# Altium schematic sheet S07_Ethernet_RevF.SchDoc
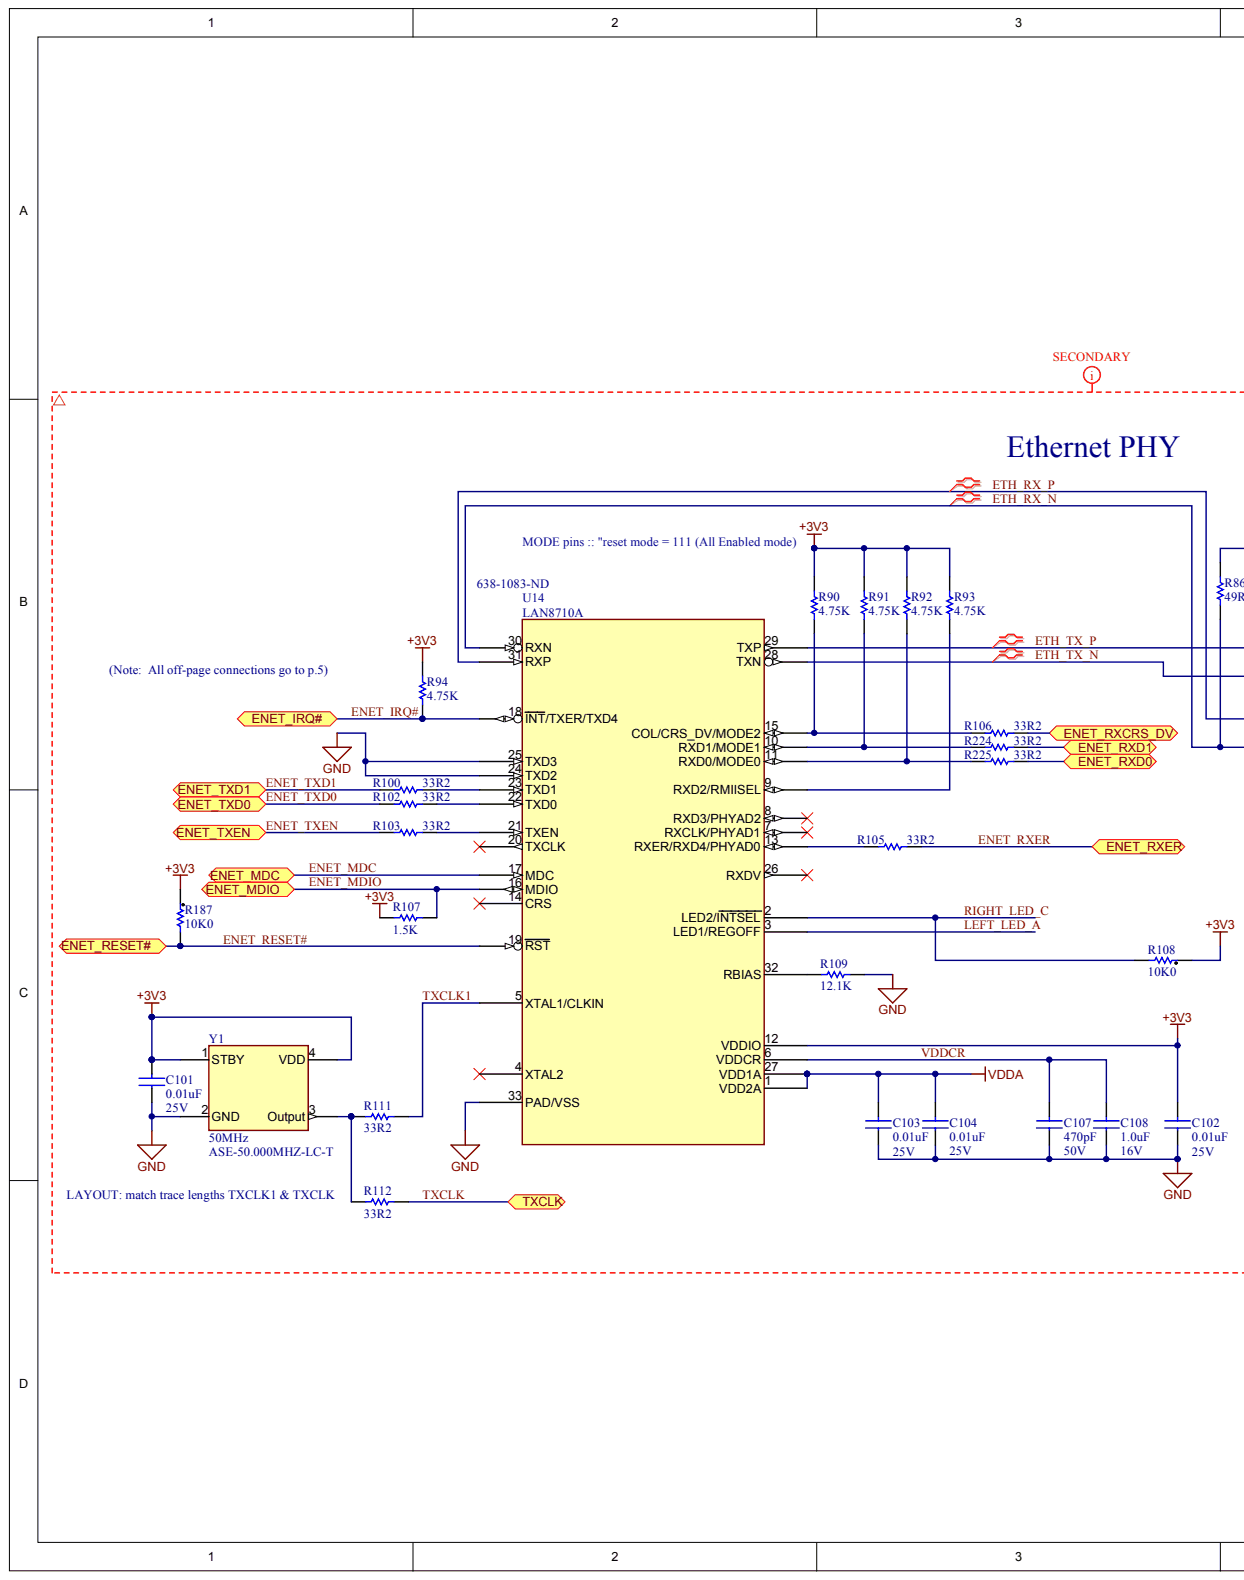
[diagram p0: S07_Ethernet_RevF.SchDoc, left]
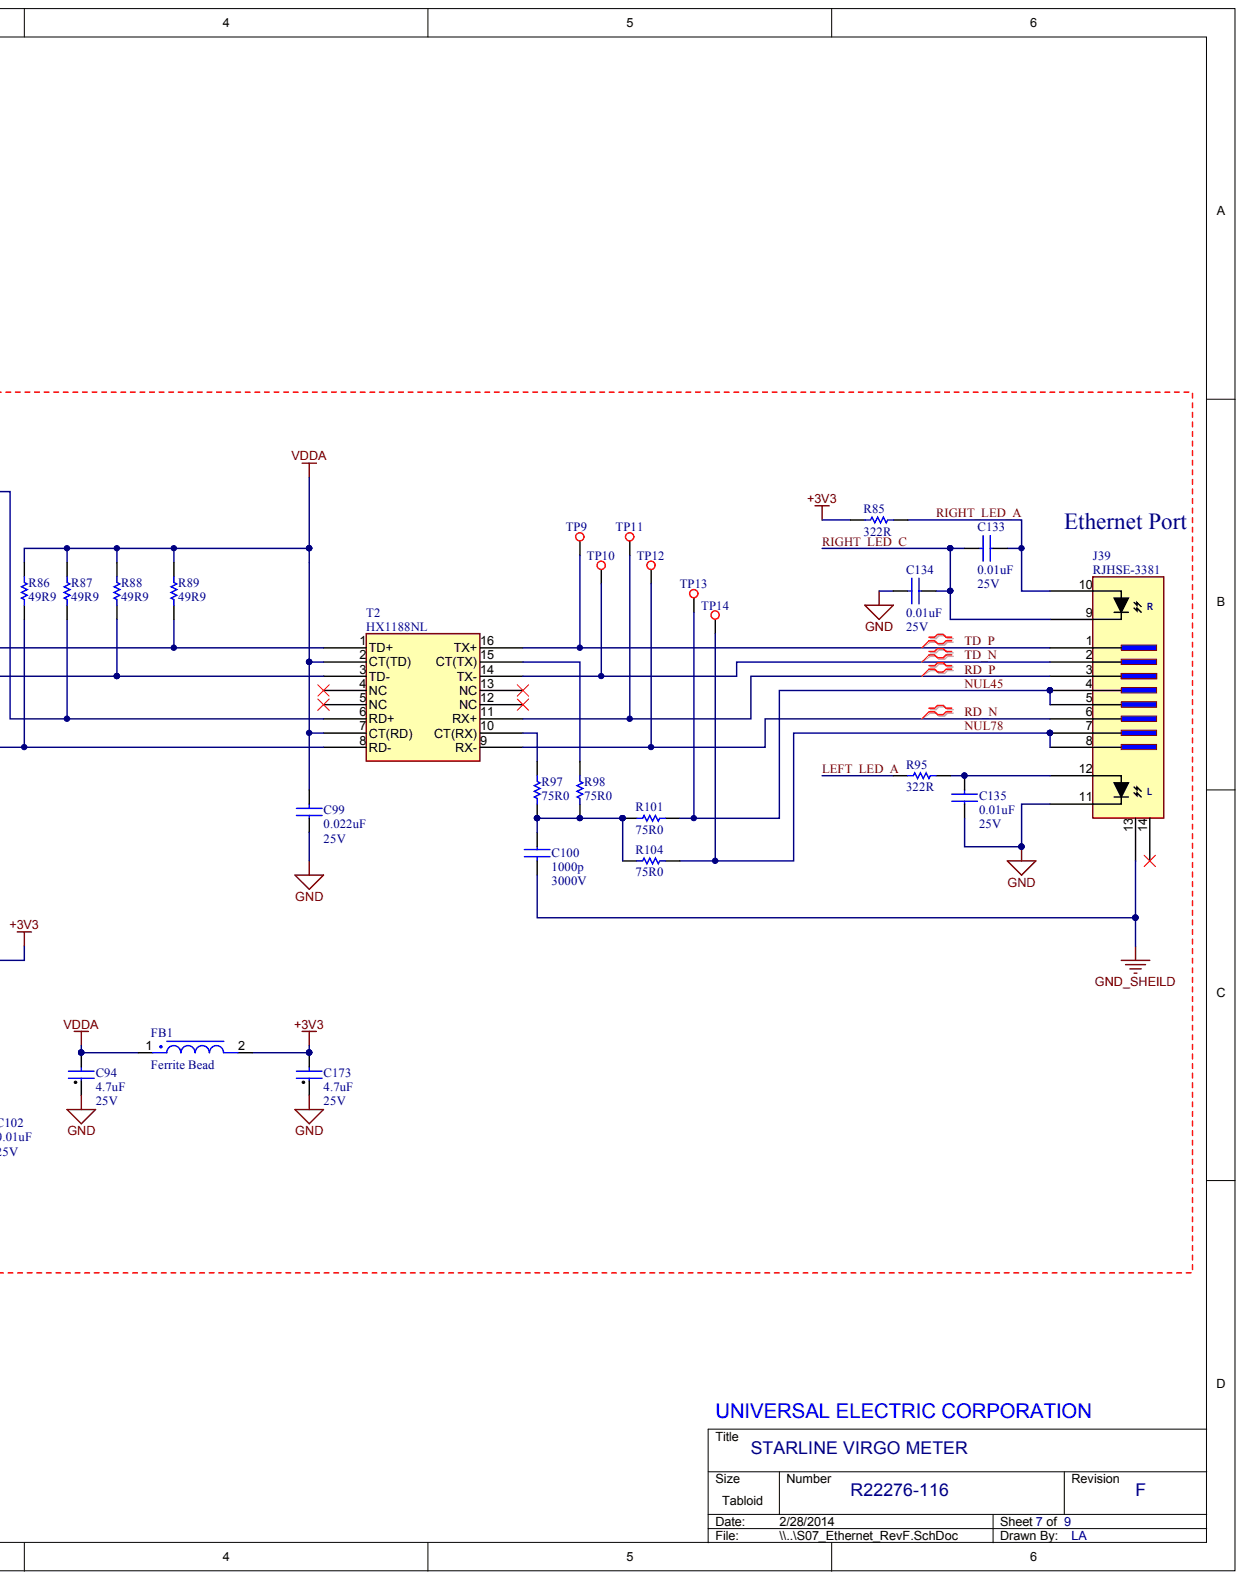
[diagram p1: S07_Ethernet_RevF.SchDoc, right]

## Parts
C94  Voltage: 25V  (hidden comment: 4.7uF)
C99  value 0.022uF  Voltage: 25V  (hidden comment: CAP CER 0.022uF X7R 10% 25V SM_0402)
C100  VOLTAGE: 3000V  (hidden comment: 1000p)
C101  value 0.01uF  Voltage: 25V  (hidden comment: CAP CER 0.01uF X7R 10% 25V SM_0402)
C102  value 0.01uF  Voltage: 25V  (hidden comment: CAP CER 0.01uF X7R 10% 25V SM_0402)
C103  value 0.01uF  Voltage: 25V  (hidden comment: CAP CER 0.01uF X7R 10% 25V SM_0402)
C104  value 0.01uF  Voltage: 25V  (hidden comment: CAP CER 0.01uF X7R 10% 25V SM_0402)
C107  value 470pF  Voltage: 50V  (hidden comment: CAP CER 470pF C0G 5% 50V SM_0402)
C108  value 1.0uF  Voltage: 16V  (hidden comment: CAP CER 1.0uF X7R 20% 16V SM_0805)
C133  value 0.01uF  Voltage: 25V  (hidden comment: CAP CER 0.01uF X7R 10% 25V SM_0402)
C134  value 0.01uF  Voltage: 25V  (hidden comment: CAP CER 0.01uF X7R 10% 25V SM_0402)
C135  value 0.01uF  Voltage: 25V  (hidden comment: CAP CER 0.01uF X7R 10% 25V SM_0402)
C173  Voltage: 25V  (hidden comment: 4.7uF)
FB1  Ferrite Bead
J39  value RJHSE-3381  MFG_PART_NO: RJHSE-3381  (hidden comment: RJ45)
R85  value 322R  (hidden comment: RES 332R 1/16W 1% SM_0402)
R86  value 49R9  (hidden comment: RES 49R9 1/16W 1% SM_0402)
R87  value 49R9  (hidden comment: RES 49R9 1/16W 1% SM_0402)
R88  value 49R9  (hidden comment: RES 49R9 1/16W 1% SM_0402)
R89  value 49R9  (hidden comment: RES 49R9 1/16W 1% SM_0402)
R90  value 4.75K  (hidden comment: RES 4.75K 1/16W 1% SM_0402)
R91  value 4.75K  (hidden comment: RES 4.75K 1/16W 1% SM_0402)
R92  value 4.75K  (hidden comment: RES 4.75K 1/16W 1% SM_0402)
R93  value 4.75K  (hidden comment: RES 4.75K 1/16W 1% SM_0402)
R94  value 4.75K  (hidden comment: RES 4.75K 1/16W 1% SM_0402)
R95  value 322R  (hidden comment: RES 332R 1/16W 1% SM_0402)
R97  value 75R0  (hidden comment: RES 75R0 1/16W 1% SM_0402)
R98  value 75R0  (hidden comment: RES 75R0 1/16W 1% SM_0402)
R100  value 33R2  (hidden comment: RES 33R2 1/16W 1% SM_0402)
R101  value 75R0  (hidden comment: RES 75R0 1/16W 1% SM_0402)
R102  value 33R2  (hidden comment: RES 33R2 1/16W 1% SM_0402)
R103  value 33R2  (hidden comment: RES 33R2 1/16W 1% SM_0402)
R104  value 75R0  (hidden comment: RES 75R0 1/16W 1% SM_0402)
R105  value 33R2  (hidden comment: RES 33R2 1/16W 1% SM_0402)
R106  value 33R2  (hidden comment: RES 33R2 1/16W 1% SM_0402)
R107  value 1.5K  (hidden comment: RES 1.5K 1/16W 1% SM_0402)
R108  10K0
R109  value 12.1K  (hidden comment: RES 12.1K 1/16W 1% SM_0402)
R111  value 33R2  (hidden comment: RES 33R2 1/16W 1% SM_0402)
R112  value 33R2  (hidden comment: RES 33R2 1/16W 1% SM_0402)
R187  10K0
R224  value 33R2  (hidden comment: RES 33R2 1/16W 1% SM_0402)
R225  value 33R2  (hidden comment: RES 33R2 1/16W 1% SM_0402)
T2  MFG_PART_NO: HX1188NL  (hidden comment: 1:1)
TP9  =VALUE
TP10  =VALUE
TP11  =VALUE
TP12  =VALUE
TP13  =VALUE
TP14  =VALUE
U14  Supplier Part Number 1: 638-1083-ND  (hidden comment: LAN8710A)
Y1  MFG_PART_NO: ASE-50.000MHZ-LC-T  (hidden comment: 50MHz)

## Ports
ENET_IRQ#, ENET_MDC, ENET_MDIO, ENET_RESET#, ENET_RXCRS_DV, ENET_RXD0, ENET_RXD1, ENET_RXER, ENET_TXD0, ENET_TXD1, ENET_TXEN, TXCLK

## Nets
+3V3: C101.2 C102.2 C173.2 FB1.2 R85.1 R90.2 R91.2 R92.2 R93.2 R94.2 R107.1 R108.1 R187.1 U14.12(VDDIO) Y1.1(STBY) Y1.4(VDD)
GND: C94.1 C99.1 C101.1 C102.1 C103.1 C104.1 C107.1 C108.1 C134.2 C135.1 C173.1 J39.11 R109.2 U14.24(TXD2) U14.25(TXD3) U14.33(PAD/VSS) Y1.2(GND)
GND_SHEILD: C100.2 J39.13
VDDA: C94.2 C99.2 C103.2 C104.2 FB1.1 R86.2 R87.2 R88.2 R89.2 T2.2(CT(TD)) T2.7(CT(RD)) U14.1(VDD2A) U14.27(VDD1A)
ENET_IRQ#: R94.1 U14.18(INT/TXER/TXD4) ; port ENET_IRQ#
ENET_MDC: U14.17(MDC) ; port ENET_MDC
ENET_MDIO: R107.2 U14.16(MDIO) ; port ENET_MDIO
ENET_RESET#: R187.2 U14.19(RST) ; port ENET_RESET#
ENET_RXCRS_DV: R106.2 ; port ENET_RXCRS_DV
ENET_RXD0: R225.2 ; port ENET_RXD0
ENET_RXD1: R224.2 ; port ENET_RXD1
ENET_RXER: R105.2 ; port ENET_RXER
ENET_TXD0: R102.1 ; port ENET_TXD0
ENET_TXD1: R100.1 ; port ENET_TXD1
ENET_TXEN: R103.1 ; port ENET_TXEN
ETH_RX_N: R86.1 T2.8(RD-) U14.30(RXN)
ETH_RX_P: R87.1 T2.6(RD+) U14.31(RXP)
ETH_TX_N: R88.1 T2.3(TD-) U14.28(TXN)
ETH_TX_P: R89.1 T2.1(TD+) U14.29(TXP)
LEFT_LED_A: R95.1 U14.3(LED1/REGOFF)
NUL45: J39.4 J39.5 R101.1 TP13.1
NUL78: J39.7 J39.8 R104.1 TP14.1
RD_N: J39.6 T2.9(RX-) TP12.1
RD_P: J39.3 T2.11(RX+) TP11.1
RIGHT_LED_A: C133.1 J39.10 R85.2
RIGHT_LED_C: C133.2 C134.1 J39.9 R108.2 U14.2(LED2/INTSEL)
TD_N: J39.2 T2.14(TX-) TP10.1
TD_P: J39.1 T2.16(TX+) TP9.1
TXCLK: R112.2 ; port TXCLK
TXCLK1: R111.2 U14.5(XTAL1/CLKIN)
VDDCR: C107.2 C108.2 U14.6(VDDCR)
NetC100_1: C100.1 R97.1 R98.1 R101.2 R104.2
NetC135_2: C135.2 J39.12 R95.2
NetR90_1: R90.1 R106.1 U14.15(COL/CRS_DV/MODE2)
NetR91_1: R91.1 R224.1 U14.10(RXD1/MODE1)
NetR92_1: R92.1 R225.1 U14.11(RXD0/MODE0)
NetR93_1: R93.1 U14.9(RXD2/RMIISEL)
NetR97_2: R97.2 T2.10(CT(RX))
NetR98_2: R98.2 T2.15(CT(TX))
NetR100_2: R100.2 U14.23(TXD1)
NetR102_2: R102.2 U14.22(TXD0)
NetR103_2: R103.2 U14.21(TXEN)
NetR105_1: R105.1 U14.13(RXER/RXD4/PHYAD0)
NetR109_1: R109.1 U14.32(RBIAS)
NetR111_1: R111.1 R112.1 Y1.3(Output)
N00382:

Not connected: J39 14; T2 4(NC) 5(NC) 12(NC) 13(NC); U14 4(XTAL2) 7(RXCLK/PHYAD1) 8(RXD3/PHYAD2) 14(CRS) 20(TXCLK) 26(RXDV)

## Library data of the parts
C94 C173 = (no symbol name): MFG_PART_NO: GRM21BR61E475KA12L; MFG: Murata; Value: 4.7uF
C99 = (no symbol name): MFG_PART_NO: C1005X7R1E223K; MFG: TDK
C100 = (no symbol name): MFG_PART_NO: C1808X102KHRACTU; LEVEL: Level_1; MFG: Kemet; TYPE: Capacitor; TOLERANCE: +/- 10%; NUV_DESCRIPTION: CAP CER 1000PF 3KV 10% X7R 1808; NOSTUFF: *; ROOM: *; VALUE: 1000p; PACKAGE: SMT; ROHS: RoHS; LAST_UPDATE: 1/18/2012; Created By: K Desrochers
C101-C104 C133-C135 = (no symbol name): MFG_PART_NO: GRM155R71E103KA01D; MFG: Murata
C107 = (no symbol name): MFG_PART_NO: GRM1555C1H471JA01D; MFG: Murata
C108 = (no symbol name): MFG_PART_No: C2012X7R1C105K; MFG: TDK
FB1 = (no symbol name): Manufacturer P/N: MZ1608-102Y; Manufacturer: Bourns; Frequency: 100MHZ; Current Rating: 100mA; Package: SM_0603
J39 = (no symbol name): LEVEL: Level_1; MFG: Amphenol; NUV_DESCRIPTION: RJ45 Ethernet Jacl Thru-Hole Vertical; NOSTUFF: *; TYPE: Connector; PACKAGE: Thru-Hole; ROHS: RoHS; LAST_UPDATE: 6/22/2012; Created By: K Desrochers
R85 R95 = (no symbol name): MFG_PART_NO: CRCW0402332RFKED; MFG: Vishay
R86-R89 = (no symbol name): MFG_PART_NO: CRCW040249R9FKED; MFG: Vishay
R90-R94 = (no symbol name): MFG_PART_NO: CRCW04024K75FKED; MFG: Vishay
R97 R98 R101 R104 = (no symbol name): MFG_PART_NO: CRCW040275R0FKED; MFG: Vishay
R100 R102 R103 R105 R106 R111 R112 R224 R225 = (no symbol name): MFG_PART_NO: CRCW040233R2FKED; MFG: Vishay
R107 = (no symbol name): MFG_PART_NO: CRCW04021K5FKED; MFG: Vishay
R108 R187 = (no symbol name): Part Number: CRCW040210K0FKED; Manufacturer: Vishay; Power: 1/16W; Tolerance: 0.01; RESISTANCE: 10K0; Manufacturer P/N: CRCW040210K0FKED; Package: SMT; Type: Resistor
R109 = (no symbol name): MFG_PART_NO: CRCW040212K1FKED; MFG: Vishay
T2 = (no symbol name): LEVEL: Level_1; MFG: Pulse; TYPE: Transformer; NUV_DESCRIPTION: MODULE XFRMR SGL ETHR LAN 16SOIC; VALUE: 1:1; PACKAGE: SMT; ROHS: RoHS; LAST_UPDATE: 6/22/2012; Created By: K Desrochers
TP9-TP14 = (no symbol name): SYM_LVL: LEVEL1; LEVEL: Level_1; NUV_DESCRIPTION: Test Point; ROOM: *; NOSTUFF: NOSTUFF; TYPE: Test Point; PACKAGE: SMT; ROHS: RoHS; LAST_UPDATE: 6/21/2012; Created By: K Desrochers
U14 = (no symbol name): MFG_PART_NO: LAN8710AI-EZK; LEVEL: Level_1; PCBLIB: UEC01.PcbLib; MFG: SMSC; NOTES: Footprint created using LP Wizard; NUV_DESCRIPTION: Single-Chip Ethernet Physical Layer Transceiver; TYPE: IC; VALUE: LAN8710A; PACKAGE: QFN-32; ROHS: RoHS; LAST_UPDATE: 6/21/2012; Supplier 1: Digi-Key; Created By: K Desrochers
Y1 = (no symbol name): LEVEL: Level_1; PCBLIB: UEC01.PcbLib; MFG: ASE; NUV_DESCRIPTION: OSCILLATOR 50.0 MHZ 3.3V SMD; TYPE: Oscillator; VALUE: 50MHz; PACKAGE: SMT; ROHS: RoHS; Created By: H.Munir
